# Altium schematic sheet IR_Emitters.SchDoc(IR_Emitters)
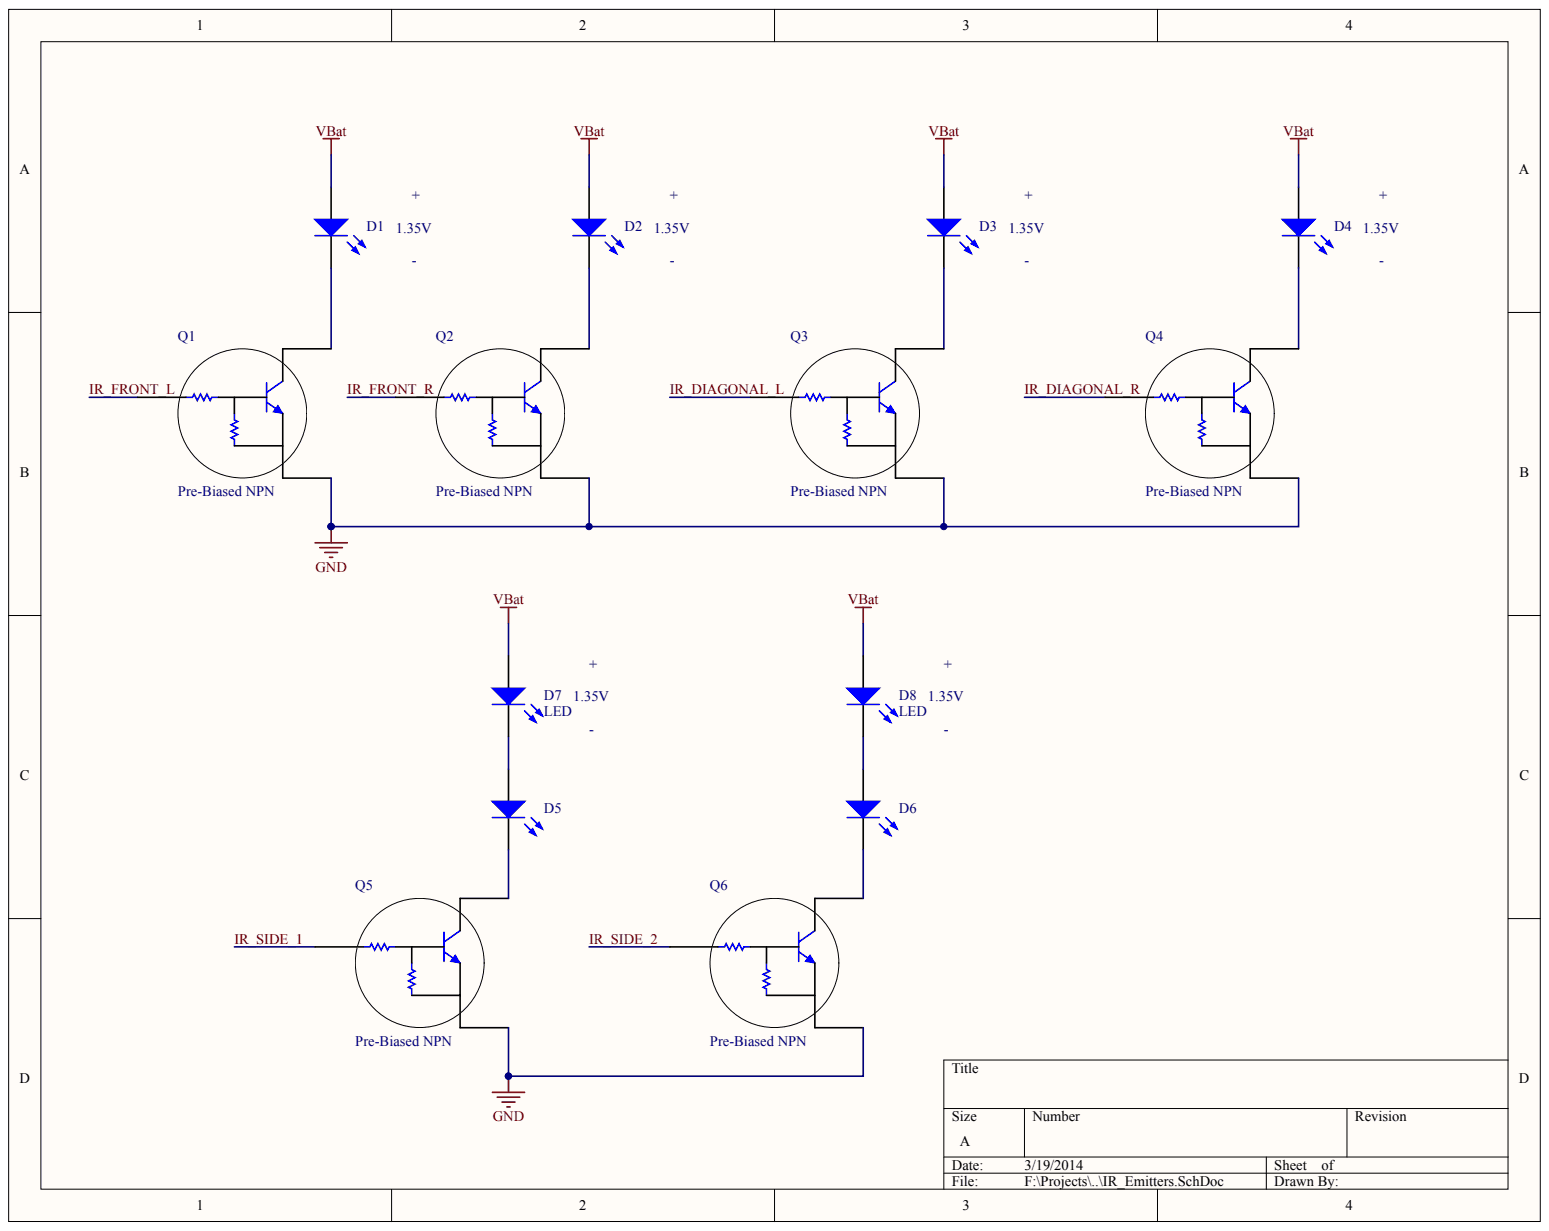
[diagram p0: IR_Emitters.SchDoc]

## Parts
D1  LED  (value not drawn)
D2  LED  (value not drawn)
D3  LED  (value not drawn)
D4  LED  (value not drawn)
D5  LED  (value not drawn)
D6  LED  (value not drawn)
D7  LED
D8  LED
Q1  Pre-Biased NPN
Q2  Pre-Biased NPN
Q3  Pre-Biased NPN
Q4  Pre-Biased NPN
Q5  Pre-Biased NPN
Q6  Pre-Biased NPN

## Nets
GND: Q1.2 Q2.2 Q3.2 Q4.2 Q5.2 Q6.2
VBAT: D1.1 D2.1 D3.1 D4.1 D7.1 D8.1
IR_DIAGONAL_L: Q3.1
IR_DIAGONAL_R: Q4.1
IR_FRONT_L: Q1.1
IR_FRONT_R: Q2.1
IR_SIDE_1: Q5.1
IR_SIDE_2: Q6.1
NetD1_2: D1.2 Q1.3
NetD2_2: D2.2 Q2.3
NetD3_2: D3.2 Q3.3
NetD4_2: D4.2 Q4.3
NetD5_1: D5.1 D7.2
NetD5_2: D5.2 Q5.3
NetD6_1: D6.1 D8.2
NetD6_2: D6.2 Q6.3

## Library data of the parts
D1-D8 = (no symbol name): Vendor Part Number: 751-1203-ND; Manufacturer: Vishay; Manufacturer Part Number: TSAL6100; Vendor: Digikey; Published: 16-Mar-2013; LatestRevisionDate: 16-Mar-2013; LatestRevisionNote: Created; PackageReference: LED; PackageDescription: 5mm LED; 2 Leads; Publisher: Anthony Merlino
Q1-Q6 = (no symbol name): Manufacturer Part No: DTD743EETL; Vendor Part No: DTD743EETLTR-ND; Vendor: Digikey
